# Altium schematic sheet FPGA_GPIO.SchDoc(FPGA_GPIO)
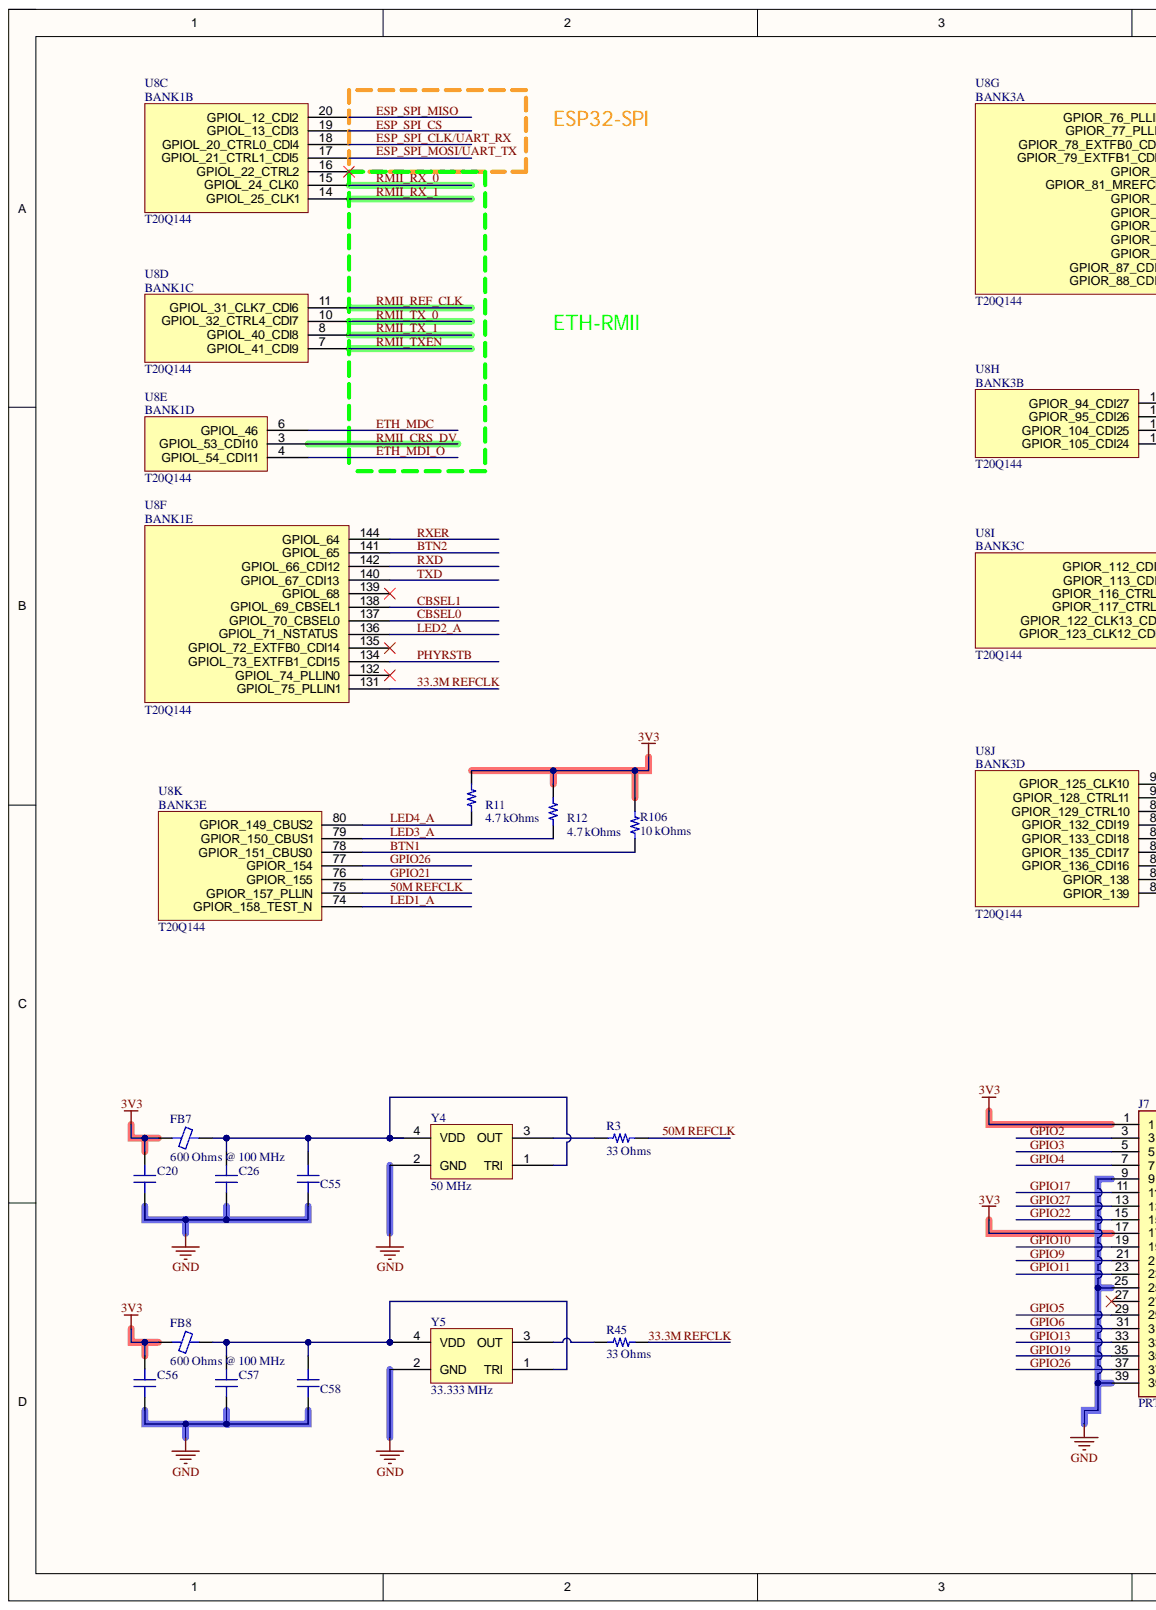
[diagram p0: FPGA_GPIO.SchDoc, left]
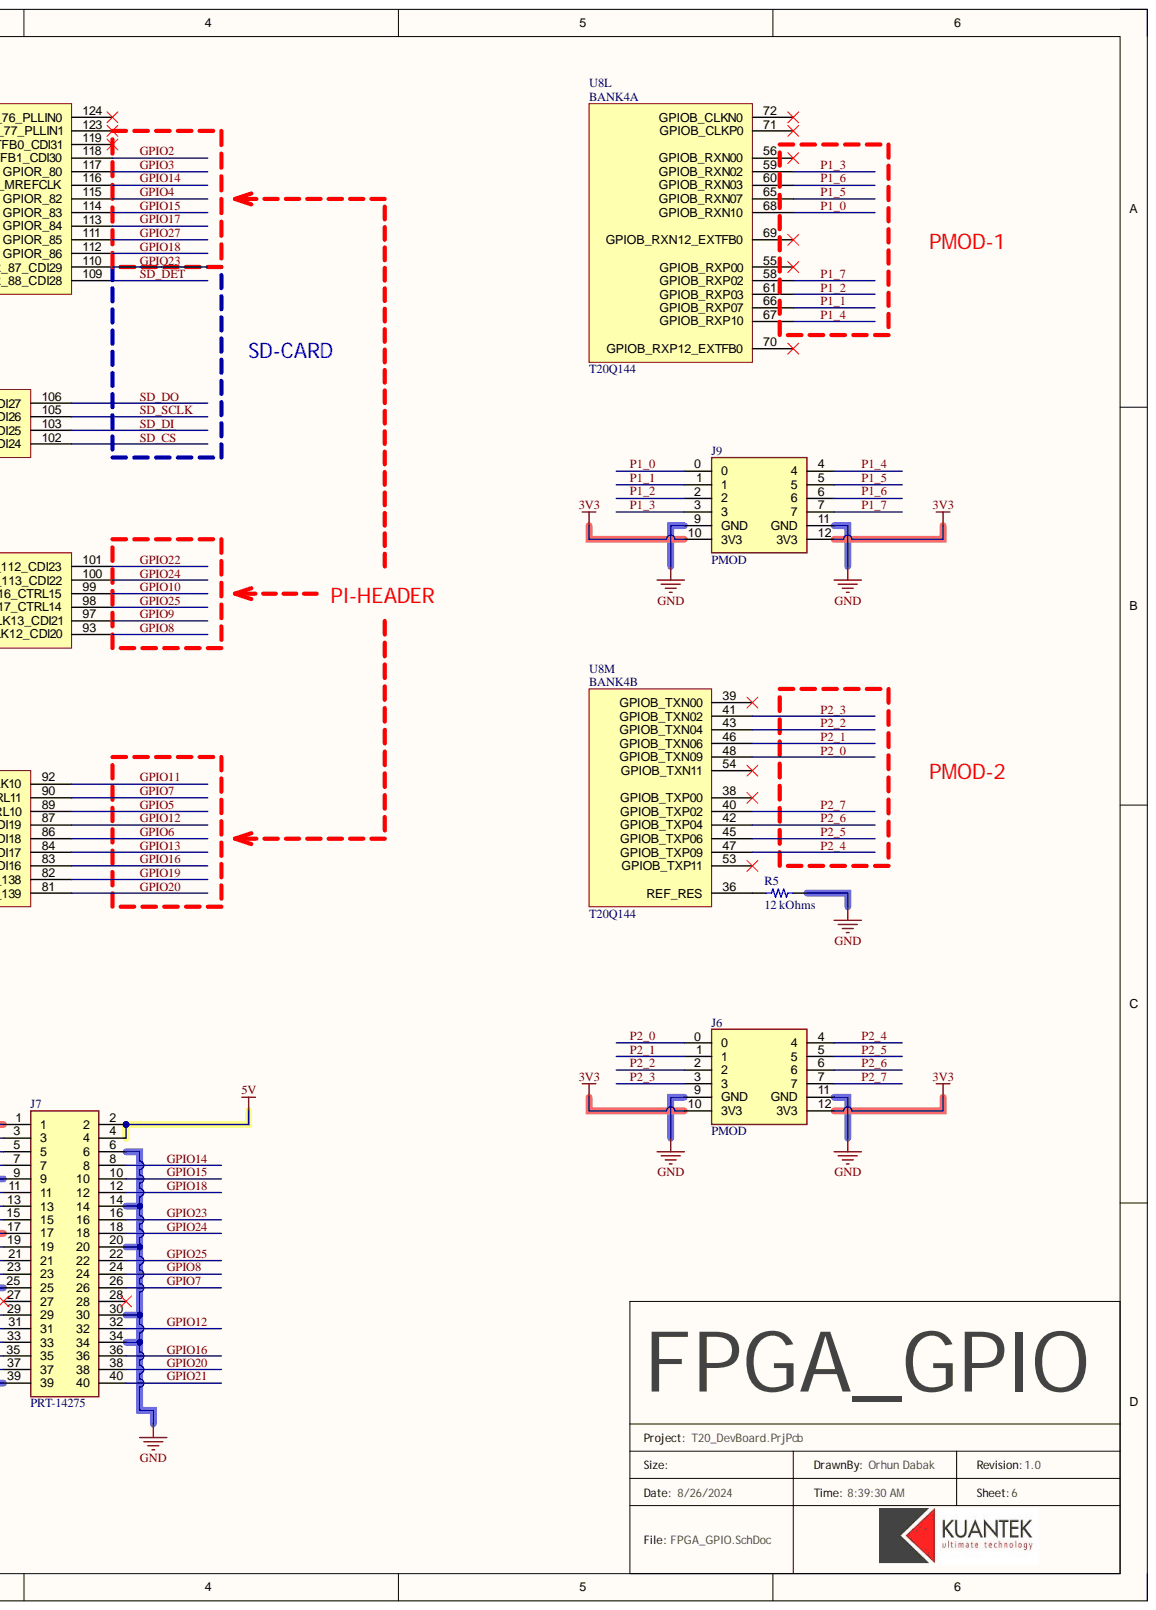
[diagram p1: FPGA_GPIO.SchDoc, right]

## Parts
C20  4.7 µF  [CAP 0402_1005]  (value not drawn)
C26  4.7 µF  [CAP 0402_1005]  (value not drawn)
C55  10000 pF  [CAP 0402_1005]  (value not drawn)
C56  4.7 µF  [CAP 0402_1005]  (value not drawn)
C57  4.7 µF  [CAP 0402_1005]  (value not drawn)
C58  10000 pF  [CAP 0402_1005]  (value not drawn)
FB7  600 Ohms @ 100 MHz  [FER 0402_1005]
FB8  600 Ohms @ 100 MHz  [FER 0402_1005]
J6  PMOD
J7  PRT-14275  [HDRV40W64P254_2X20_5080X500X884P]
J9  PMOD
R3  33 Ohms  [RES 0402_1005]
R5  12 kOhms  [RES 0402_1005]
R11  4.7 kOhms  [RES 0402_1005]
R12  4.7 kOhms  [RES 0402_1005]
R45  33 Ohms  [RES 0402_1005]
R106  10 kOhms  [RES 0402_1005]
U8  T20Q144  [CQFP50P2200X2200X160-144N]  (parts C,D,E,F,G,H,I,J,K,L,M)
Y4  50 MHz  [ABRACON ASE]
Y5  33.333 MHz  [ABRACON ASE]

## Nets
3V3: C20.2 C56.2 FB7.1 FB8.1 J6.10(3V3) J6.12(3V3) J7.1 J7.17 J9.10(3V3) J9.12(3V3) R11.2 R12.2 R106.2
5V: J7.2 J7.4
GND: C20.1 C26.1 C55.1 C56.1 C57.1 C58.1 J6.9(GND) J6.11(GND) J7.6 J7.9 J7.14 J7.20 J7.25 J7.30 J7.34 J7.39 J9.9(GND) J9.11(GND) R5.1 Y4.2(GND) Y5.2(GND)
33.3M REFCLK: R45.2 U8.131(GPIOL_75_PLLIN1)
50M REFCLK: R3.2 U8.75(GPIOR_157_PLLIN)
BTN1: R106.1 U8.78(GPIOR_151_CBUS0)
BTN2: U8.141(GPIOL_65)
CBSEL0: U8.137(GPIOL_70_CBSEL0)
CBSEL1: U8.138(GPIOL_69_CBSEL1)
ESP_SPI_CLK/UART_RX: U8.18(GPIOL_20_CTRL0_CDI4)
ESP_SPI_CS: U8.19(GPIOL_13_CDI3)
ESP_SPI_MISO: U8.20(GPIOL_12_CDI2)
ESP_SPI_MOSI/UART_TX: U8.17(GPIOL_21_CTRL1_CDI5)
ETH_MDC: U8.6(GPIOL_46)
ETH_MDI_O: U8.4(GPIOL_54_CDI11)
GPIO2: J7.3 U8.118(GPIOR_79_EXTFB1_CDI30)
GPIO3: J7.5 U8.117(GPIOR_80)
GPIO4: J7.7 U8.115(GPIOR_82)
GPIO5: J7.29 U8.89(GPIOR_129_CTRL10)
GPIO6: J7.31 U8.86(GPIOR_133_CDI18)
GPIO7: J7.26 U8.90(GPIOR_128_CTRL11)
GPIO8: J7.24 U8.93(GPIOR_123_CLK12_CDI20)
GPIO9: J7.21 U8.97(GPIOR_122_CLK13_CDI21)
GPIO10: J7.19 U8.99(GPIOR_116_CTRL15)
GPIO11: J7.23 U8.92(GPIOR_125_CLK10)
GPIO12: J7.32 U8.87(GPIOR_132_CDI19)
GPIO13: J7.33 U8.84(GPIOR_135_CDI17)
GPIO14: J7.8 U8.116(GPIOR_81_MREFCLK)
GPIO15: J7.10 U8.114(GPIOR_83)
GPIO16: J7.36 U8.83(GPIOR_136_CDI16)
GPIO17: J7.11 U8.113(GPIOR_84)
GPIO18: J7.12 U8.112(GPIOR_86)
GPIO19: J7.35 U8.82(GPIOR_138)
GPIO20: J7.38 U8.81(GPIOR_139)
GPIO21: J7.40 U8.76(GPIOR_155)
GPIO22: J7.15 U8.101(GPIOR_112_CDI23)
GPIO23: J7.16 U8.110(GPIOR_87_CDI29)
GPIO24: J7.18 U8.100(GPIOR_113_CDI22)
GPIO25: J7.22 U8.98(GPIOR_117_CTRL14)
GPIO26: J7.37 U8.77(GPIOR_154)
GPIO27: J7.13 U8.111(GPIOR_85)
LED1_A: U8.74(GPIOR_158_TEST_N)
LED2_A: U8.136(GPIOL_71_NSTATUS)
LED3_A: R12.1 U8.79(GPIOR_150_CBUS1)
LED4_A: R11.1 U8.80(GPIOR_149_CBUS2)
P1_0: J9.0 U8.68(GPIOB_RXN10)
P1_1: J9.1 U8.66(GPIOB_RXP07)
P1_2: J9.2 U8.61(GPIOB_RXP03)
P1_3: J9.3 U8.59(GPIOB_RXN02)
P1_4: J9.4 U8.67(GPIOB_RXP10)
P1_5: J9.5 U8.65(GPIOB_RXN07)
P1_6: J9.6 U8.60(GPIOB_RXN03)
P1_7: J9.7 U8.58(GPIOB_RXP02)
P2_0: J6.0 U8.48(GPIOB_TXN09)
P2_1: J6.1 U8.46(GPIOB_TXN06)
P2_2: J6.2 U8.43(GPIOB_TXN04)
P2_3: J6.3 U8.41(GPIOB_TXN02)
P2_4: J6.4 U8.47(GPIOB_TXP09)
P2_5: J6.5 U8.45(GPIOB_TXP06)
P2_6: J6.6 U8.42(GPIOB_TXP04)
P2_7: J6.7 U8.40(GPIOB_TXP02)
PHYRSTB: U8.134(GPIOL_73_EXTFB1_CDI15)
RMII_CRS_DV: U8.3(GPIOL_53_CDI10)
RMII_REF_CLK: U8.11(GPIOL_31_CLK7_CDI6)
RMII_RX_0: U8.15(GPIOL_24_CLK0)
RMII_RX_1: U8.14(GPIOL_25_CLK1)
RMII_TX_0: U8.10(GPIOL_32_CTRL4_CDI7)
RMII_TX_1: U8.8(GPIOL_40_CDI8)
RMII_TXEN: U8.7(GPIOL_41_CDI9)
RXD: U8.142(GPIOL_66_CDI12)
RXER: U8.144(GPIOL_64)
~{SD_CS}: U8.102(GPIOR_105_CDI24)
~{SD_DET}: U8.109(GPIOR_88_CDI28)
SD_DI: U8.103(GPIOR_104_CDI25)
SD_DO: U8.106(GPIOR_94_CDI27)
SD_SCLK: U8.105(GPIOR_95_CDI26)
TXD: U8.140(GPIOL_67_CDI13)
NetC26_2: C26.2 C55.2 FB7.2 Y4.1(TRI) Y4.4(VDD)
NetC57_2: C57.2 C58.2 FB8.2 Y5.1(TRI) Y5.4(VDD)
NetR3_1: R3.1 Y4.3(OUT)
NetR5_2: R5.2 U8.36(REF_RES)
NetR45_1: R45.1 Y5.3(OUT)

Not connected: J7 27 28; U8 16(GPIOL_22_CTRL2) 38(GPIOB_TXP00) 39(GPIOB_TXN00) 53(GPIOB_TXP11) 54(GPIOB_TXN11) 55(GPIOB_RXP00) 56(GPIOB_RXN00) 69(GPIOB_RXN12_EXTFB0) 70(GPIOB_RXP12_EXTFB0) 71(GPIOB_CLKP0) 72(GPIOB_CLKN0) 119(GPIOR_78_EXTFB0_CDI31) 123(GPIOR_77_PLLIN1) 124(GPIOR_76_PLLIN0) 132(GPIOL_74_PLLIN0) 135(GPIOL_72_EXTFB0_CDI14) 139(GPIOL_68)

## Library data of the parts
C20 C26 C56 C57 = CL05A475MP5NRNC: CAP CER 4.7UF 10V X5R 0402; manufacturer: Samsung Electro-Mechanics; Database Table Name: Capacitors - Ceramic - 0402; Tolerance: ±20%; Applications: General Purpose; Capacitance: 4.7 µF; Component Kind: Standard; componentlink1description: Datasheet; componentlink1url: http://www.samsungsem.com/kr/support/product-search/mlcc/CL05A475MP5NRNC.jsp; componentlink2description: DigiKey Link; componentlink2url: https://www.digikey.com/en/products/detail/samsung-electro-mechanics/CL05A475MP5NRNC/3887140; Device Package: 0402 (1005 Metric); lastupdated: 19/09/2022 12:57:17; Library Name: Celestial Altium Library - altium_library.DbLib; Lifecycle Status: Active; Minimum Order: 1; Mounting Type: Surface Mount, MLCC; Operating Temperature: -55°C ~ 85°C; Package / Case: 0402 (1005 Metric); packaging: TapeAndReel; Part Number: CL05A475MP5NRNC; Part Status: Active; partid: 7650; price: 0.01; series: CL; Size / Dimension: 0.039" L x 0.020" W (1.00mm x 0.50mm); Supplier 1: DigiKey; Supplier Part Number 1: 1276-1482-1-ND; Temperature Coefficient: X5R; Thickness (Max): 0.022" (0.55mm); Value: 4.7 µF; Voltage - Rated: 10V
C55 C58 = C0402C103K5RECAUTO: CAP CER 0402 10NF 50V X7R 10%; manufacturer: KEMET; Database Table Name: Capacitors - Ceramic - 0402; Applications: Automotive, ESD Protection; Capacitance: 10000 pF; Component Kind: Standard; componentlink1description: Datasheet; componentlink1url: https://api.kemet.com/component-edge/download/datasheet/C0402C103K5RECAUTO.pdf; componentlink2description: DigiKey Link; componentlink2url: https://www.digikey.com/en/products/detail/kemet/C0402C103K5RECAUTO/8646477; Device Package: 0402 (1005 Metric); lastupdated: 19/09/2022 12:56:43; Library Name: Celestial Altium Library - altium_library.DbLib; Lifecycle Status: Active; Minimum Order: 1; Mounting Type: Surface Mount, MLCC; Operating Temperature: -55°C ~ 125°C; Package / Case: 0402 (1005 Metric); packaging: TapeAndReel; Part Number: C0402C103K5RECAUTO; Part Status: Active; partid: 38916; price: 0.01; Ratings: AEC-Q200; series: ESD SMD Auto X7R; Size / Dimension: 0.039" L x 0.020" W (1.00mm x 0.50mm); Supplier 1: DigiKey; Supplier Part Number 1: 399-C0402C103K5RECAUTOCT-ND; Temperature Coefficient: X7R; Thickness (Max): 0.022" (0.55mm); Tolerance: ±10%; Value: 10000 pF; Voltage - Rated: 50V
FB7 FB8 = BLM15AG601SN1D: FERRITE BEAD 600 OHM 0402 1LN; Manufacturer: Murata Electronics; Current Rating (Max): 300mA; Database Table Name: Filters - Ferrite Beads and Chips; DC Resistance (DCR) (Max): 520mOhm; Number of Lines: 1; Component Kind: Standard; ComponentLink1Description: Datasheet; ComponentLink1URL: https://www.murata.com/en-us/products/productdata/8796740059166/ENFA0018.pdf; ComponentLink2Description: DigiKey Link; ComponentLink2URL: https://www.digikey.com/en/products/detail/murata-electronics/BLM15AG601SN1D/584217; Device Package: 0402 (1005 Metric); Height (Max): 0.022" (0.55mm); Impedance @ Frequency: 600 Ohms @ 100 MHz; LastUpdated: 1/26/2023 08:43:34 PM; Library Name: Celestial Altium Library - altium_library.DbLib; Lifecycle Status: Active; Minimum Order: 1; Mounting Type: Surface Mount; Operating Temperature: -55°C ~ 125°C; Package / Case: 0402 (1005 Metric); Packaging: TapeAndReel; Part Number: BLM15AG601SN1D; Part Status: Active; PartId: 386; Price: 0.01; Series: EMIFIL®, BLM15; Size / Dimension: 0.039" L x 0.022" W (1.00mm x 0.55mm); Supplier 1: DigiKey; Supplier Part Number 1: 490-1006-1-ND; Value: 600 Ohms @ 100 MHz
J7 = PRT-14275: Connector; Manufacturer_Name: SparkFun; Arrow Part Number: PRT-14275; Arrow Price/Stock: https://www.arrow.com/en/products/prt-14275/sparkfun-electronics?region=nac; Component Kind: Standard; Datasheet Link: https://componentsearchengine.com/Datasheets/1/PRT-14275.pdf; Height: 8.84mm; Library Name: SamacSys.SchLib; Manufacturer_Part_Number: PRT-14275; Mouser Part Number: 474-PRT-14275; Mouser Price/Stock: https://www.mouser.co.uk/ProductDetail/SparkFun/PRT-14275?qs=YCa%2FAAYMW01SLG%2FRsDUFug%3D%3D
R3 R45 = RC0402FR-0733RL: RES 33 OHM 1% 1/16W 0402; Manufacturer: Yageo; Database Table Name: Resistors - Surface Mount - 0402; Power (Watts): 0.063W, 1/16W; Temperature Coefficient: ±100ppm/°C; Component Kind: Standard; ComponentLink1Description: Datasheet; ComponentLink1URL: https://www.yageo.com/upload/media/product/productsearch/datasheet/rchip/PYu-RC_Group_51_RoHS_L_10.pdf; ComponentLink2Description: DigiKey Link; ComponentLink2URL: https://www.digikey.com/en/products/detail/yageo/RC0402FR-0733RL/726593; Composition: Thick Film; Device Package: 0402 (1005 Metric); Features: Moisture Resistant; Height - Seated (Max): 0.016" (0.40mm); LastUpdated: 19/09/2022 13:14:04; Library Name: Celestial Altium Library - altium_library.DbLib; Lifecycle Status: Active; Minimum Order: 1; Number of Terminations: 2; Operating Temperature: -55°C ~ 155°C; Package / Case: 0402 (1005 Metric); Packaging: CutTape; Part Number: RC0402FR-0733RL; Part Status: Active; PartId: 20555; Price: 0.01; Resistance: 33 Ohms; Series: RC_L; Size / Dimension: 0.039" L x 0.020" W (1.00mm x 0.50mm); Supplier 1: DigiKey; Supplier Device Package: 0402; Supplier Part Number 1: 311-33.0LRCT-ND; Tolerance: ±1%; Value: 33 Ohms
R5 = CPF0402B12KE1: RES SMD 12K OHM 0.1% 1/16W 0402; Manufacturer: TE Connectivity Passive Product; Database Table Name: Resistors - Surface Mount - 0402; Power (Watts): 0.063W, 1/16W; Temperature Coefficient: ±25ppm/°C; Component Kind: Standard; ComponentLink1Description: Datasheet; ComponentLink1URL: https://www.te.com/commerce/DocumentDelivery/DDEController?Action=srchrtrv&DocNm=1773200&DocType=DS&DocLang=English; ComponentLink2Description: DigiKey Link; ComponentLink2URL: https://www.digikey.com/en/products/detail/te-connectivity-passive-product/CPF0402B12KE1/2381830; Composition: Thin Film; Device Package: 0402 (1005 Metric); Height - Seated (Max): 0.014" (0.35mm); LastUpdated: 1/2/2023 08:21:48 PM; Library Name: Celestial Altium Library - altium_library.DbLib; Lifecycle Status: Active; Minimum Order: 1; Number of Terminations: 2; Operating Temperature: -55°C ~ 155°C; Package / Case: 0402 (1005 Metric); Packaging: TapeAndReel; Part Number: CPF0402B12KE1; Part Status: Active; PartId: 3318; Price: 0.13; Resistance: 12 kOhms; Series: CPF, Neohm; Size / Dimension: 0.039" L x 0.020" W (1.00mm x 0.50mm); Supplier 1: DigiKey; Supplier Device Package: 0402; Supplier Part Number 1: A102603CT-ND; Tolerance: ±0.1%; Value: 12 kOhms
R11 R12 = RT0402FRE074K7L: RES SMD 4.7K OHM 1% 1/16W 0402; Manufacturer: Yageo; Database Table Name: Resistors - Surface Mount - 0402; Power (Watts): 0.063W, 1/16W; Temperature Coefficient: ±50ppm/°C; Component Kind: Standard; ComponentLink1Description: Datasheet; ComponentLink1URL: https://www.yageo.com/upload/media/product/productsearch/datasheet/rchip/PYu-RT_1-to-0.01_RoHS_L_11.pdf; ComponentLink2Description: DigiKey Link; ComponentLink2URL: https://www.digikey.com/en/products/detail/yageo/RT0402FRE074K7L/1072052; Composition: Thin Film; Device Package: 0402 (1005 Metric); Height - Seated (Max): 0.014" (0.35mm); LastUpdated: 1/2/2023 08:21:49 PM; Library Name: Celestial Altium Library - altium_library.DbLib; Lifecycle Status: Active; Minimum Order: 1; Number of Terminations: 2; Operating Temperature: -55°C ~ 155°C; Package / Case: 0402 (1005 Metric); Packaging: TapeAndReel; Part Number: RT0402FRE074K7L; Part Status: Active; PartId: 3198; Price: 0.01; Resistance: 4.7 kOhms; Series: RT; Size / Dimension: 0.039" L x 0.020" W (1.00mm x 0.50mm); Supplier 1: DigiKey; Supplier Device Package: 0402; Supplier Part Number 1: YAG3347CT-ND; Tolerance: ±1%; Value: 4.7 kOhms
R106 = RC0402JR-0710KL: RES 10K OHM 5% 1/16W 0402; Manufacturer: Yageo; Database Table Name: Resistors - Surface Mount - 0402; Power (Watts): 0.063W, 1/16W; Temperature Coefficient: ±100ppm/°C; Component Kind: Standard; ComponentLink1Description: Datasheet; ComponentLink1URL: https://www.yageo.com/upload/media/product/productsearch/datasheet/rchip/PYu-RC_Group_51_RoHS_L_10.pdf; ComponentLink2Description: DigiKey Link; ComponentLink2URL: https://www.digikey.com/en/products/detail/yageo/RC0402JR-0710KL/726418; Composition: Thick Film; Device Package: 0402 (1005 Metric); Features: Moisture Resistant; Height - Seated (Max): 0.016" (0.40mm); LastUpdated: 4/7/2023 07:22:44 PM; Library Name: Celestial Altium Library - altium_library.DbLib; Lifecycle Status: Active; Minimum Order: 1; Number of Terminations: 2; Operating Temperature: -55°C ~ 155°C; Package / Case: 0402 (1005 Metric); Packaging: CutTape; Part Number: RC0402JR-0710KL; Part Status: Active; PartId: 20526; Price: 0.01; Resistance: 10 kOhms; Series: RC_L; Size / Dimension: 0.039" L x 0.020" W (1.00mm x 0.50mm); Supplier 1: DigiKey; Supplier Device Package: 0402; Supplier Part Number 1: 311-10KJRCT-ND; Tolerance: ±5%; Value: 10 kOhms
U8 = T20Q144: Trion® Field Programmable Gate Array (FPGA) IC 97 1044 19728 144-LQFP; Component Kind: Standard; Library Name: T8_devkit_PCB.SchLib
Y4 = ASE-50.000MHZ-ET: XTAL OSC XO 50.0000MHZ CMOS SMD; Manufacturer: Abracon LLC; Base Resonator: Crystal; Current - Supply (Max): 19mA; Database Table Name: Oscillators - Oscillators; Frequency Stability: ±100ppm; Function: Enable/Disable; Voltage - Supply: 3.3V; Component Kind: Standard; ComponentLink1Description: Datasheet; ComponentLink1URL: https://abracon.com/Oscillators/ASEseries.pdf; ComponentLink2Description: DigiKey Link; ComponentLink2URL: https://www.digikey.com/en/products/detail/abracon-llc/ASE-50-000MHZ-ET/2001363; Frequency: 50 MHz; Height - Seated (Max): 0.047" (1.20mm); LastUpdated: 1/25/2023 08:51:43 PM; Library Name: Celestial Altium Library - altium_library.DbLib; Lifecycle Status: Active; Minimum Order: 1; Mounting Type: Surface Mount; Operating Temperature: -20°C ~ 70°C; Output: CMOS; Package / Case: 4-SMD, No Lead; Packaging: TapeAndReel; Part Number: ASE-50.000MHZ-ET; Part Status: Active; PartId: 115; Price: 0.70; Series: ASE; Size / Dimension: 0.126" L x 0.098" W (3.20mm x 2.50mm); Supplier 1: DigiKey; Supplier Part Number 1: 535-9996-1-ND; Type: XO (Standard); Value: 50 MHz
Y5 = ASE-33.333MHZ-LC-T: XTAL OSC XO 33.3330MHZ CMOS SMD; Manufacturer: Abracon LLC; Base Resonator: Crystal; Current - Supply (Max): 13mA; Database Table Name: Oscillators - Oscillators; Frequency Stability: ±50ppm; Function: Enable/Disable; Voltage - Supply: 3.3V; Component Kind: Standard; ComponentLink1Description: Datasheet; ComponentLink1URL: https://abracon.com/Oscillators/ASEseries.pdf; ComponentLink2Description: DigiKey Link; ComponentLink2URL: https://www.digikey.com/en/products/detail/abracon-llc/ASE-33-333MHZ-LC-T/1236891; Frequency: 33.333 MHz; Height - Seated (Max): 0.047" (1.20mm); LastUpdated: 1/25/2023 08:51:43 PM; Library Name: Celestial Altium Library - altium_library.DbLib; Lifecycle Status: Active; Minimum Order: 1; Mounting Type: Surface Mount; Operating Temperature: -40°C ~ 85°C; Output: CMOS; Package / Case: 4-SMD, No Lead; Packaging: TapeAndReel; Part Number: ASE-33.333MHZ-LC-T; Part Status: Active; PartId: 128; Price: 0.76; Series: ASE; Size / Dimension: 0.126" L x 0.098" W (3.20mm x 2.50mm); Supplier 1: DigiKey; Supplier Part Number 1: 535-9574-1-ND; Type: XO (Standard); Value: 33.333 MHz
J6 J9 = PMOD: Component Kind: Standard; Library Name: LWIR.SchLib
